# Altium schematic sheet 10 TYPEC.SchDoc
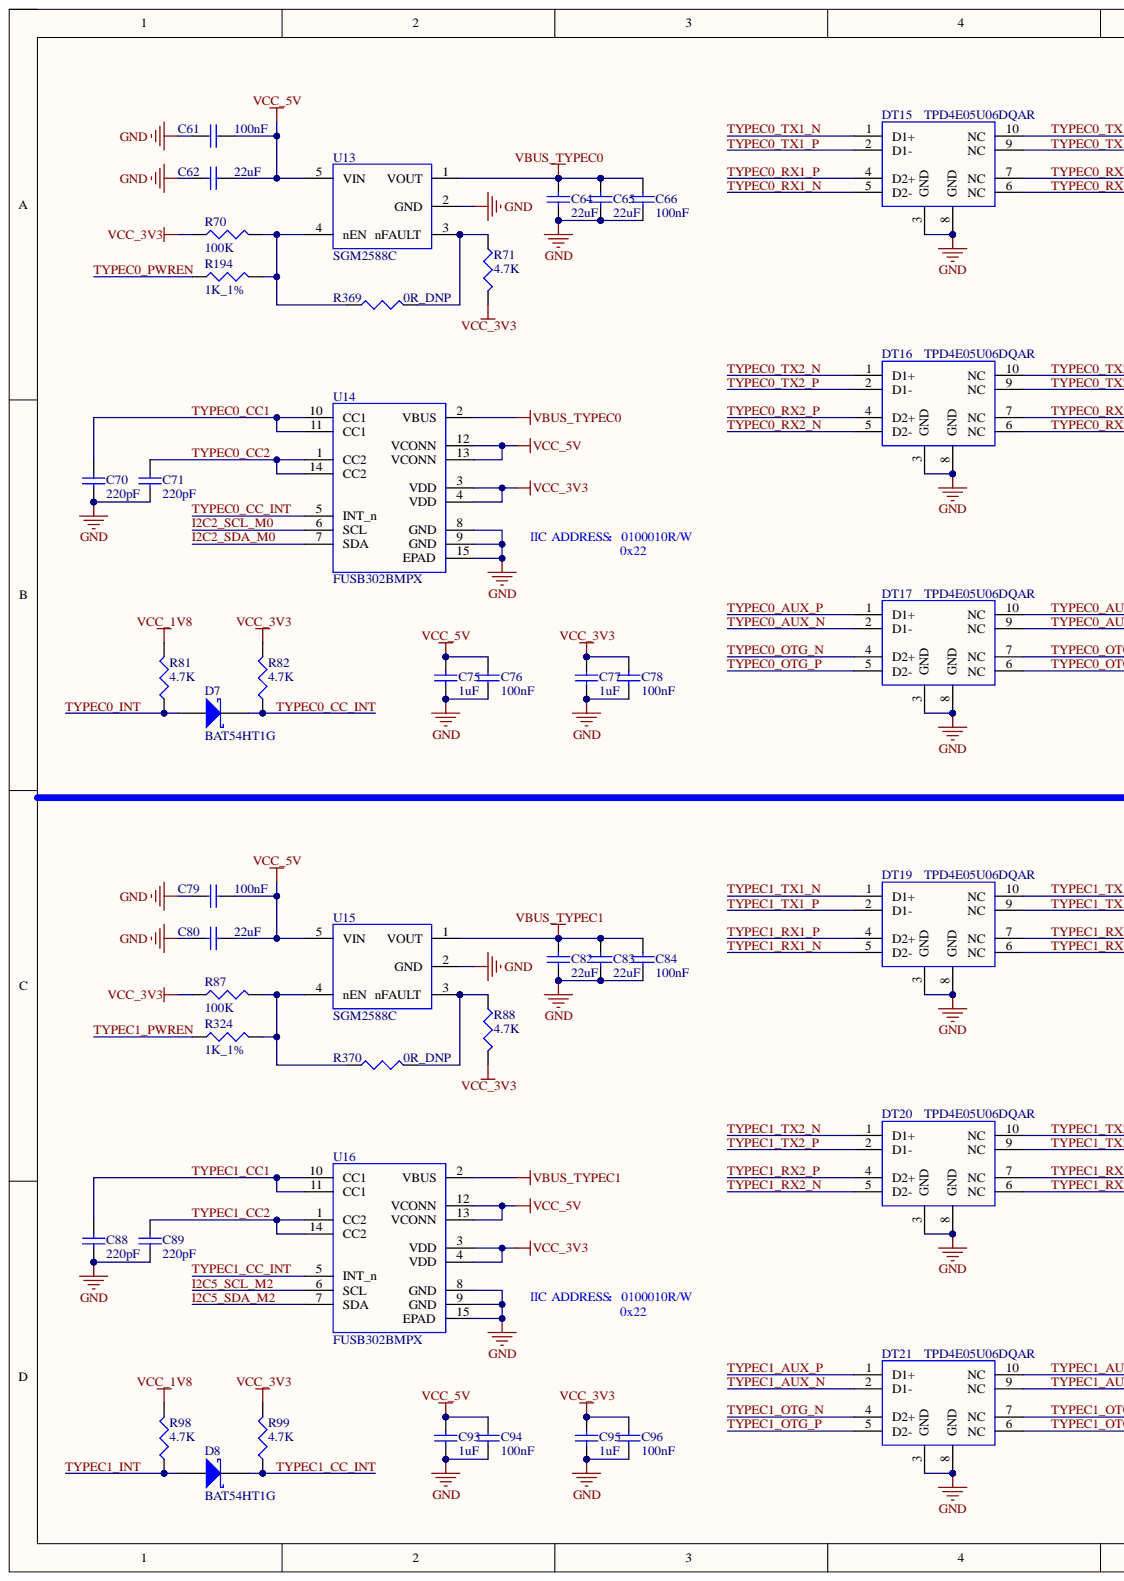
[diagram p0: 10 TYPEC.SchDoc, left]
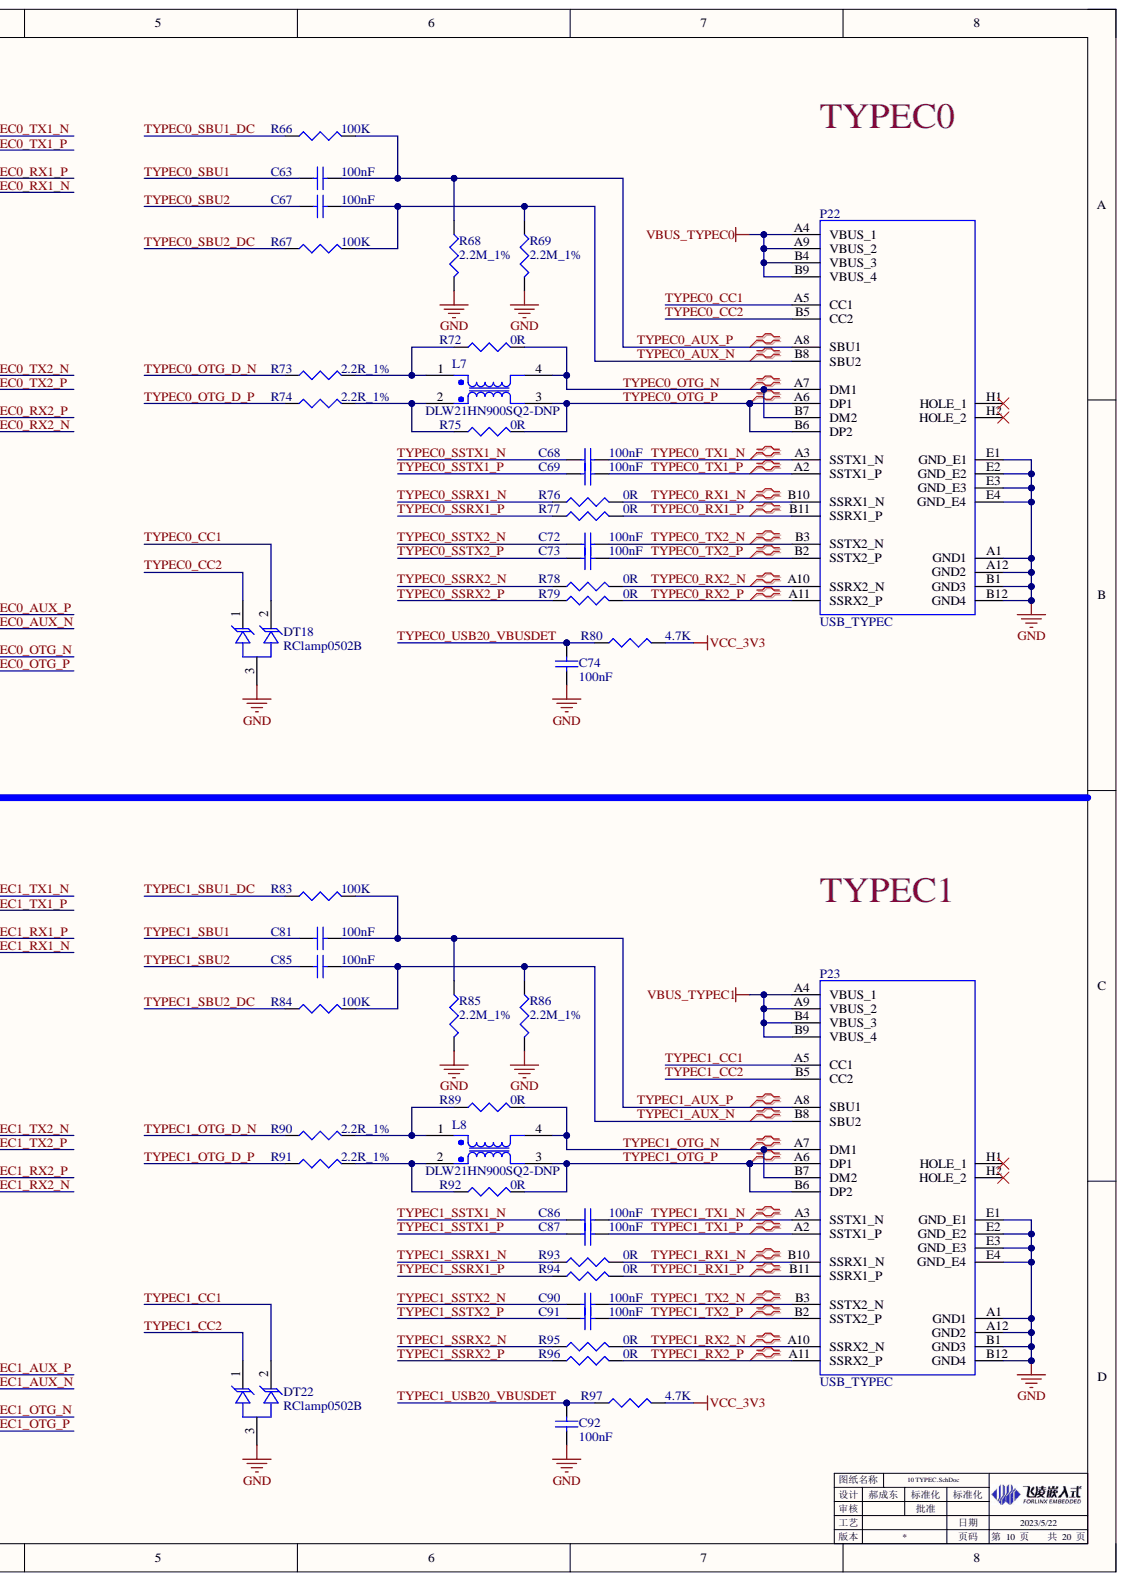
[diagram p1: 10 TYPEC.SchDoc, right]

## Parts
C61  100nF  [0402C]
C62  22uF  [0805C]
C63  100nF  [0201C]
C64  22uF  [0805C]
C65  22uF  [0805C]
C66  100nF  [0402C]
C67  100nF  [0201C]
C68  100nF  [0201C]
C69  100nF  [0201C]
C70  220pF  [0402C]
C71  220pF  [0402C]
C72  100nF  [0201C]
C73  100nF  [0201C]
C74  100nF  [0402C]
C75  1uF  [0402C]
C76  100nF  [0402C]
C77  1uF  [0402C]
C78  100nF  [0402C]
C79  100nF  [0402C]
C80  22uF  [0805C]
C81  100nF  [0201C]
C82  22uF  [0805C]
C83  22uF  [0805C]
C84  100nF  [0402C]
C85  100nF  [0201C]
C86  100nF  [0201C]
C87  100nF  [0201C]
C88  220pF  [0402C]
C89  220pF  [0402C]
C90  100nF  [0201C]
C91  100nF  [0201C]
C92  100nF  [0402C]
C93  1uF  [0402C]
C94  100nF  [0402C]
C95  1uF  [0402C]
C96  100nF  [0402C]
D7  BAT54HT1G  [SOD_323]
D8  BAT54HT1G  [SOD_323]
DT15  TPD4E05U06DQAR  [USON-10]
DT16  TPD4E05U06DQAR  [USON-10]
DT17  TPD4E05U06DQAR  [USON-10]
DT18  RClamp0502B  [SOT_523]
DT19  TPD4E05U06DQAR  [USON-10]
DT20  TPD4E05U06DQAR  [USON-10]
DT21  TPD4E05U06DQAR  [USON-10]
DT22  RClamp0502B  [SOT_523]
L7  DLW21HN900SQ2-DNP  [L_CHK_2012]
L8  DLW21HN900SQ2-DNP  [L_CHK_2012]
P22  USB_TYPEC  [USB_TYPEC_SMT]
P23  USB_TYPEC  [USB_TYPEC_SMT]
R66  100K  [0402R]
R67  100K  [0402R]
R68  2.2M_1%  [0201R]
R69  2.2M_1%  [0201R]
R70  100K  [0402R]
R71  4.7K  [0402R]
R72  0R  [0402R]
R73  2.2R_1%  [0402R]
R74  2.2R_1%  [0402R]
R75  0R  [0402R]
R76  0R  [0201R]
R77  0R  [0201R]
R78  0R  [0201R]
R79  0R  [0201R]
R80  4.7K  [0402R]
R81  4.7K  [0402R]
R82  4.7K  [0402R]
R83  100K  [0402R]
R84  100K  [0402R]
R85  2.2M_1%  [0201R]
R86  2.2M_1%  [0201R]
R87  100K  [0402R]
R88  4.7K  [0402R]
R89  0R  [0402R]
R90  2.2R_1%  [0402R]
R91  2.2R_1%  [0402R]
R92  0R  [0402R]
R93  0R  [0201R]
R94  0R  [0201R]
R95  0R  [0201R]
R96  0R  [0201R]
R97  4.7K  [0402R]
R98  4.7K  [0402R]
R99  4.7K  [0402R]
R194  1K_1%  [0402R]
R324  1K_1%  [0402R]
R369  0R_DNP  [0402R]
R370  0R_DNP  [0402R]
U13  SGM2588C  [SOT_235]
U14  FUSB302BMPX  [WQFN14_0.5_2.5x2.5]
U15  SGM2588C  [SOT_235]
U16  FUSB302BMPX  [WQFN14_0.5_2.5x2.5]

## Nets
GND: C61.2 C62.2 C64.1 C65.1 C66.1 C70.2 C71.2 C74.1 C75.1 C76.1 C77.1 C78.1 C79.2 C80.2 C82.1 C83.1 C84.1 C88.2 C89.2 C92.1 C93.1 C94.1 C95.1 C96.1 DT15.3(GND) DT15.8(GND) DT16.3(GND) DT16.8(GND) DT17.3(GND) DT17.8(GND) DT18.3 DT19.3(GND) DT19.8(GND) DT20.3(GND) DT20.8(GND) DT21.3(GND) DT21.8(GND) DT22.3 P22.A1(GND1) P22.A12(GND2) P22.B1(GND3) P22.B12(GND4) P22.E1(GND_E1) P22.E2(GND_E2) P22.E3(GND_E3) P22.E4(GND_E4) P23.A1(GND1) P23.A12(GND2) P23.B1(GND3) P23.B12(GND4) P23.E1(GND_E1) P23.E2(GND_E2) P23.E3(GND_E3) P23.E4(GND_E4) R68.2 R69.2 R85.2 R86.2 U13.2(GND) U14.8(GND) U14.9(GND) U14.15(EPAD) U15.2(GND) U16.8(GND) U16.9(GND) U16.15(EPAD)
VBUS_TYPEC0: C64.2 C65.2 C66.2 P22.A4(VBUS_1) P22.A9(VBUS_2) P22.B4(VBUS_3) P22.B9(VBUS_4) U13.1(VOUT) U14.2(VBUS)
VBUS_TYPEC1: C82.2 C83.2 C84.2 P23.A4(VBUS_1) P23.A9(VBUS_2) P23.B4(VBUS_3) P23.B9(VBUS_4) U15.1(VOUT) U16.2(VBUS)
VCC_1V8: R81.2 R98.2
VCC_3V3: C77.2 C78.2 C95.2 C96.2 R70.1 R71.2 R80.2 R82.2 R87.1 R88.2 R97.2 R99.2 U14.3(VDD) U14.4(VDD) U16.3(VDD) U16.4(VDD)
VCC_5V: C61.1 C62.1 C75.2 C76.2 C79.1 C80.1 C93.2 C94.2 U13.5(VIN) U14.12(VCONN) U14.13(VCONN) U15.5(VIN) U16.12(VCONN) U16.13(VCONN)
I2C2_SCL_M0: U14.6(SCL)
I2C2_SDA_M0: U14.7(SDA)
I2C5_SCL_M2: U16.6(SCL)
I2C5_SDA_M2: U16.7(SDA)
TYPEC0_AUX_N: C67.2 DT17.2(D1-) DT17.9(NC) P22.B8(SBU2) R67.2 R69.1
TYPEC0_AUX_P: C63.2 DT17.1(D1+) DT17.10(NC) P22.A8(SBU1) R66.2 R68.1
TYPEC0_CC1: C70.1 DT18.2 P22.A5(CC1) U14.10(CC1) U14.11(CC1)
TYPEC0_CC2: C71.1 DT18.1 P22.B5(CC2) U14.1(CC2) U14.14(CC2)
TYPEC0_CC_INT: D7.2 R82.1 U14.5(INT_n)
TYPEC0_INT: D7.1 R81.1
TYPEC0_OTG_D_N: R73.1
TYPEC0_OTG_D_P: R74.1
TYPEC0_OTG_N: DT17.4(D2+) DT17.7(NC) L7.4 P22.A7(DM1) P22.B7(DM2) R72.2
TYPEC0_OTG_P: DT17.5(D2-) DT17.6(NC) L7.3 P22.A6(DP1) P22.B6(DP2) R75.2
TYPEC0_PWREN: R194.1
TYPEC0_RX1_N: DT15.5(D2-) DT15.6(NC) P22.B10(SSRX1_N) R76.2
TYPEC0_RX1_P: DT15.4(D2+) DT15.7(NC) P22.B11(SSRX1_P) R77.2
TYPEC0_RX2_N: DT16.5(D2-) DT16.6(NC) P22.A10(SSRX2_N) R78.2
TYPEC0_RX2_P: DT16.4(D2+) DT16.7(NC) P22.A11(SSRX2_P) R79.2
TYPEC0_SBU1: C63.1
TYPEC0_SBU1_DC: R66.1
TYPEC0_SBU2: C67.1
TYPEC0_SBU2_DC: R67.1
TYPEC0_SSRX1_N: R76.1
TYPEC0_SSRX1_P: R77.1
TYPEC0_SSRX2_N: R78.1
TYPEC0_SSRX2_P: R79.1
TYPEC0_SSTX1_N: C68.1
TYPEC0_SSTX1_P: C69.1
TYPEC0_SSTX2_N: C72.1
TYPEC0_SSTX2_P: C73.1
TYPEC0_TX1_N: C68.2 DT15.1(D1+) DT15.10(NC) P22.A3(SSTX1_N)
TYPEC0_TX1_P: C69.2 DT15.2(D1-) DT15.9(NC) P22.A2(SSTX1_P)
TYPEC0_TX2_N: C72.2 DT16.1(D1+) DT16.10(NC) P22.B3(SSTX2_N)
TYPEC0_TX2_P: C73.2 DT16.2(D1-) DT16.9(NC) P22.B2(SSTX2_P)
TYPEC0_USB20_VBUSDET: C74.2 R80.1
TYPEC1_AUX_N: C85.2 DT21.2(D1-) DT21.9(NC) P23.B8(SBU2) R84.2 R86.1
TYPEC1_AUX_P: C81.2 DT21.1(D1+) DT21.10(NC) P23.A8(SBU1) R83.2 R85.1
TYPEC1_CC1: C88.1 DT22.2 P23.A5(CC1) U16.10(CC1) U16.11(CC1)
TYPEC1_CC2: C89.1 DT22.1 P23.B5(CC2) U16.1(CC2) U16.14(CC2)
TYPEC1_CC_INT: D8.2 R99.1 U16.5(INT_n)
TYPEC1_INT: D8.1 R98.1
TYPEC1_OTG_D_N: R90.1
TYPEC1_OTG_D_P: R91.1
TYPEC1_OTG_N: DT21.4(D2+) DT21.7(NC) L8.4 P23.A7(DM1) P23.B7(DM2) R89.2
TYPEC1_OTG_P: DT21.5(D2-) DT21.6(NC) L8.3 P23.A6(DP1) P23.B6(DP2) R92.2
TYPEC1_PWREN: R324.1
TYPEC1_RX1_N: DT19.5(D2-) DT19.6(NC) P23.B10(SSRX1_N) R93.2
TYPEC1_RX1_P: DT19.4(D2+) DT19.7(NC) P23.B11(SSRX1_P) R94.2
TYPEC1_RX2_N: DT20.5(D2-) DT20.6(NC) P23.A10(SSRX2_N) R95.2
TYPEC1_RX2_P: DT20.4(D2+) DT20.7(NC) P23.A11(SSRX2_P) R96.2
TYPEC1_SBU1: C81.1
TYPEC1_SBU1_DC: R83.1
TYPEC1_SBU2: C85.1
TYPEC1_SBU2_DC: R84.1
TYPEC1_SSRX1_N: R93.1
TYPEC1_SSRX1_P: R94.1
TYPEC1_SSRX2_N: R95.1
TYPEC1_SSRX2_P: R96.1
TYPEC1_SSTX1_N: C86.1
TYPEC1_SSTX1_P: C87.1
TYPEC1_SSTX2_N: C90.1
TYPEC1_SSTX2_P: C91.1
TYPEC1_TX1_N: C86.2 DT19.1(D1+) DT19.10(NC) P23.A3(SSTX1_N)
TYPEC1_TX1_P: C87.2 DT19.2(D1-) DT19.9(NC) P23.A2(SSTX1_P)
TYPEC1_TX2_N: C90.2 DT20.1(D1+) DT20.10(NC) P23.B3(SSTX2_N)
TYPEC1_TX2_P: C91.2 DT20.2(D1-) DT20.9(NC) P23.B2(SSTX2_P)
TYPEC1_USB20_VBUSDET: C92.2 R97.1
NetL7_1: L7.1 R72.1 R73.2
NetL7_2: L7.2 R74.2 R75.1
NetL8_1: L8.1 R89.1 R90.2
NetL8_2: L8.2 R91.2 R92.1
NetR70_2: R70.2 R194.2 R369.1 U13.4(nEN)
NetR71_1: R71.1 R369.2 U13.3(nFAULT)
NetR87_2: R87.2 R324.2 R370.1 U15.4(nEN)
NetR88_1: R88.1 R370.2 U15.3(nFAULT)

Not connected: P22 H1(HOLE_1) H2(HOLE_2); P23 H1(HOLE_1) H2(HOLE_2)

## Library data of the parts
C61 C66 C74 C76 C78 C79 C84 C92 C94 C96 = 1110202039: Database Table Name: VALID$; 存货名称: 贴装叠层陶瓷电容; 序号: 626; 规格型号: 0402,100nF,25V,±10％,X5R; Component Kind: Standard; LatestRevisionDate: 17-Jul-2002; LatestRevisionNote: Re-released for DXP Platform.; Library Name: Database_AD Library.DbLib; PackageDescription: Radial Cap, Thru-Hole; 2 Leads; 0.3 in Pin Spacing; PackageReference: RAD-0.3; Published: 8-Jun-2000; Signal Integrity: Cap; Simulation: CAP; Value: 100nF; 存货编码: 1110202039; Publisher: Altium Limited
C62 C64 C65 C80 C82 C83 = 1110204014: Database Table Name: VALID$; 存货名称: 贴装叠层陶瓷电容; 序号: 751; 规格型号: 0805,22uF,25V,±20％,X5R; Component Kind: Standard; LatestRevisionDate: 17-Jul-2002; LatestRevisionNote: Re-released for DXP Platform.; Library Name: Database_AD Library.DbLib; PackageDescription: Radial Cap, Thru-Hole; 2 Leads; 0.3 in Pin Spacing; PackageReference: RAD-0.3; Published: 8-Jun-2000; Signal Integrity: Cap; Simulation: CAP; Value: 22uF; 存货编码: 1110204014; Publisher: Altium Limited
C63 C67-C69 C72 C73 C81 C85-C87 C90 C91 = 1110201009: Database Table Name: VALID$; 存货名称: 贴装叠层陶瓷电容; 规格型号: 0201,100nF,25V,±10％,X5R; Component Kind: Standard; LatestRevisionDate: 17-Jul-2002; LatestRevisionNote: Re-released for DXP Platform.; Library Name: Database_AD Library.DbLib; PackageDescription: Radial Cap, Thru-Hole; 2 Leads; 0.3 in Pin Spacing; PackageReference: RAD-0.3; Published: 8-Jun-2000; Signal Integrity: Cap; Simulation: CAP; Value: 100nF; 存货编码: 1110201009; Publisher: Altium Limited
C70 C71 C88 C89 = 1110202017: Database Table Name: VALID$; 存货名称: 贴装叠层陶瓷电容; 序号: 588; 规格型号: 0402,220pF,50V,±10％,X7R; Component Kind: Standard; LatestRevisionDate: 17-Jul-2002; LatestRevisionNote: Re-released for DXP Platform.; Library Name: Database_AD Library.DbLib; PackageDescription: Radial Cap, Thru-Hole; 2 Leads; 0.3 in Pin Spacing; PackageReference: RAD-0.3; Published: 8-Jun-2000; Signal Integrity: Cap; Simulation: CAP; Value: 220pF; 存货编码: 1110202017; Publisher: Altium Limited
C75 C77 C93 C95 = 1110202021: Database Table Name: VALID$; 存货名称: 贴装叠层陶瓷电容; 序号: 600; 规格型号: 0402,1uF,16V,±10％,X5R; Component Kind: Standard; LatestRevisionDate: 17-Jul-2002; LatestRevisionNote: Re-released for DXP Platform.; Library Name: Database_AD Library.DbLib; PackageDescription: Radial Cap, Thru-Hole; 2 Leads; 0.3 in Pin Spacing; PackageReference: RAD-0.3; Published: 8-Jun-2000; Signal Integrity: Cap; Simulation: CAP; Value: 1uF; 存货编码: 1110202021; Publisher: Altium Limited
D7 D8 = 1110501028: Database Table Name: VALID$; 存货名称: 肖特基二极管; 序号: 2525; 规格型号: BAT54HT1G,SOD323; Code_JEDEC: DO-214-AA; Component Kind: Standard; LatestRevisionDate: 17-Jul-2002; LatestRevisionNote: Re-released for DXP Platform.; Library Name: Database_AD Library.DbLib; PackageDescription: DO-214-AA/SMB; 2 C-Bend Leads; Body 5.3 x 3.6 mm, inc. leads (LxW); PackageReference: SMB; Published: 8-Jun-2000; Signal Integrity: D Schottky; Simulation: SKYDIODE; Value: BAT54HT1G; 存货编码: 1110501028; Publisher: Altium Limited
DT15-DT17 DT19-DT21 = 1110503037: Database Table Name: VALID$; 存货名称: TVS管; 规格型号: TPD4E05U06DQAR,USON; Component Kind: Standard; Library Name: Database_AD Library.DbLib; Value: TPD4E05U06DQAR; 存货编码: 1110503037
DT18 DT22 = 1110503016: Database Table Name: VALID$; 存货名称: TVS管; 序号: 2598; 规格型号: RCLAMP0502B,SOT-523; Component Kind: Standard; Library Name: Database_AD Library.DbLib; Value: RClamp0502B; 存货编码: 1110503016
L7 L8 = 1110303003: Database Table Name: VALID$; 存货名称: 贴装共模电感; 序号: 1015; 规格型号: 2012(0805),90 Ohm@100MHz,330mA,DLW21HN900SQ2; Component Kind: Standard; Library Name: Database_AD Library.DbLib; Value: DLW21HN900SQ2-DNP; 存货编码: 1110303003
P22 P23 = 1120102028: Database Table Name: VALID$; 存货名称: 贴装USB座; 规格型号: TYPE-C-31-M-05,母座,双排,横卧; Component Kind: Standard; Library Name: Database_AD Library.DbLib; Value: USB_TYPEC; 存货编码: 1120102028
R66 R67 R70 R83 R84 R87 = 1110102004: Database Table Name: VALID$; 存货名称: 贴装电阻; 序号: 14; 规格型号: 0402,100K,±5％; Component Kind: Standard; Library Name: Database_AD Library.DbLib; Signal Integrity: Res; Simulation: RESISTOR; Value: 100K; 存货编码: 1110102004
R68 R69 R85 R86 = 1110101020: Database Table Name: VALID$; 存货名称: 贴装电阻; 规格型号: 0201,2.2M,±1％; Component Kind: Standard; Library Name: Database_AD Library.DbLib; Signal Integrity: Res; Simulation: RESISTOR; Value: 2.2M_1%; 存货编码: 1110101020
R71 R80-R82 R88 R97-R99 = 1110102003: Database Table Name: VALID$; 存货名称: 贴装电阻; 序号: 12; 规格型号: 0402,4.7K,±5％; Component Kind: Standard; Library Name: Database_AD Library.DbLib; Signal Integrity: Res; Simulation: RESISTOR; Value: 4.7K; 存货编码: 1110102003
R72 R75 R89 R92 R369 R370 = 1110102001: Database Table Name: VALID$; 存货名称: 贴装电阻; 序号: 10; 规格型号: 0402,0Ω,±5％; Component Kind: Standard; Library Name: Database_AD Library.DbLib; Signal Integrity: Res; Simulation: RESISTOR; 存货编码: 1110102001
  R72 R75 R89 R92: Value: 0R
  R369 R370: Value: 0R_DNP
R73 R74 R90 R91 = 1110102195: Database Table Name: VALID$; 存货名称: 贴装电阻; 规格型号: 0402,2.2Ω,±1%; Component Kind: Standard; Library Name: Database_AD Library.DbLib; Signal Integrity: Res; Simulation: RESISTOR; Value: 2.2R_1%; 存货编码: 1110102195
R76-R79 R93-R96 = 1110101001: Database Table Name: VALID$; 存货名称: 贴装电阻; 序号: 556; 规格型号: 0201,0Ω,±5%; Component Kind: Standard; Library Name: Database_AD Library.DbLib; Signal Integrity: Res; Simulation: RESISTOR; Value: 0R; 存货编码: 1110101001
R194 R324 = 1110102011: Database Table Name: VALID$; 存货名称: 贴装电阻; 序号: 21; 规格型号: 0402,1K,±1％; Component Kind: Standard; Library Name: Database_AD Library.DbLib; Signal Integrity: Res; Simulation: RESISTOR; Value: 1K_1%; 存货编码: 1110102011
U13 U15 = SGM2588D: Component Kind: Standard; Library Name: OK3588-C V1.0.SchLib; value: SGM2588C
U14 U16 = 1110405272: Database Table Name: VALID$; 存货名称: 常规IC; 规格型号: FUSB302BMPX,WQFN-14; Component Kind: Standard; Library Name: Database_AD Library.DbLib; Value: FUSB302BMPX; 存货编码: 1110405272
